# Altium schematic sheet Sheet1.SchDoc
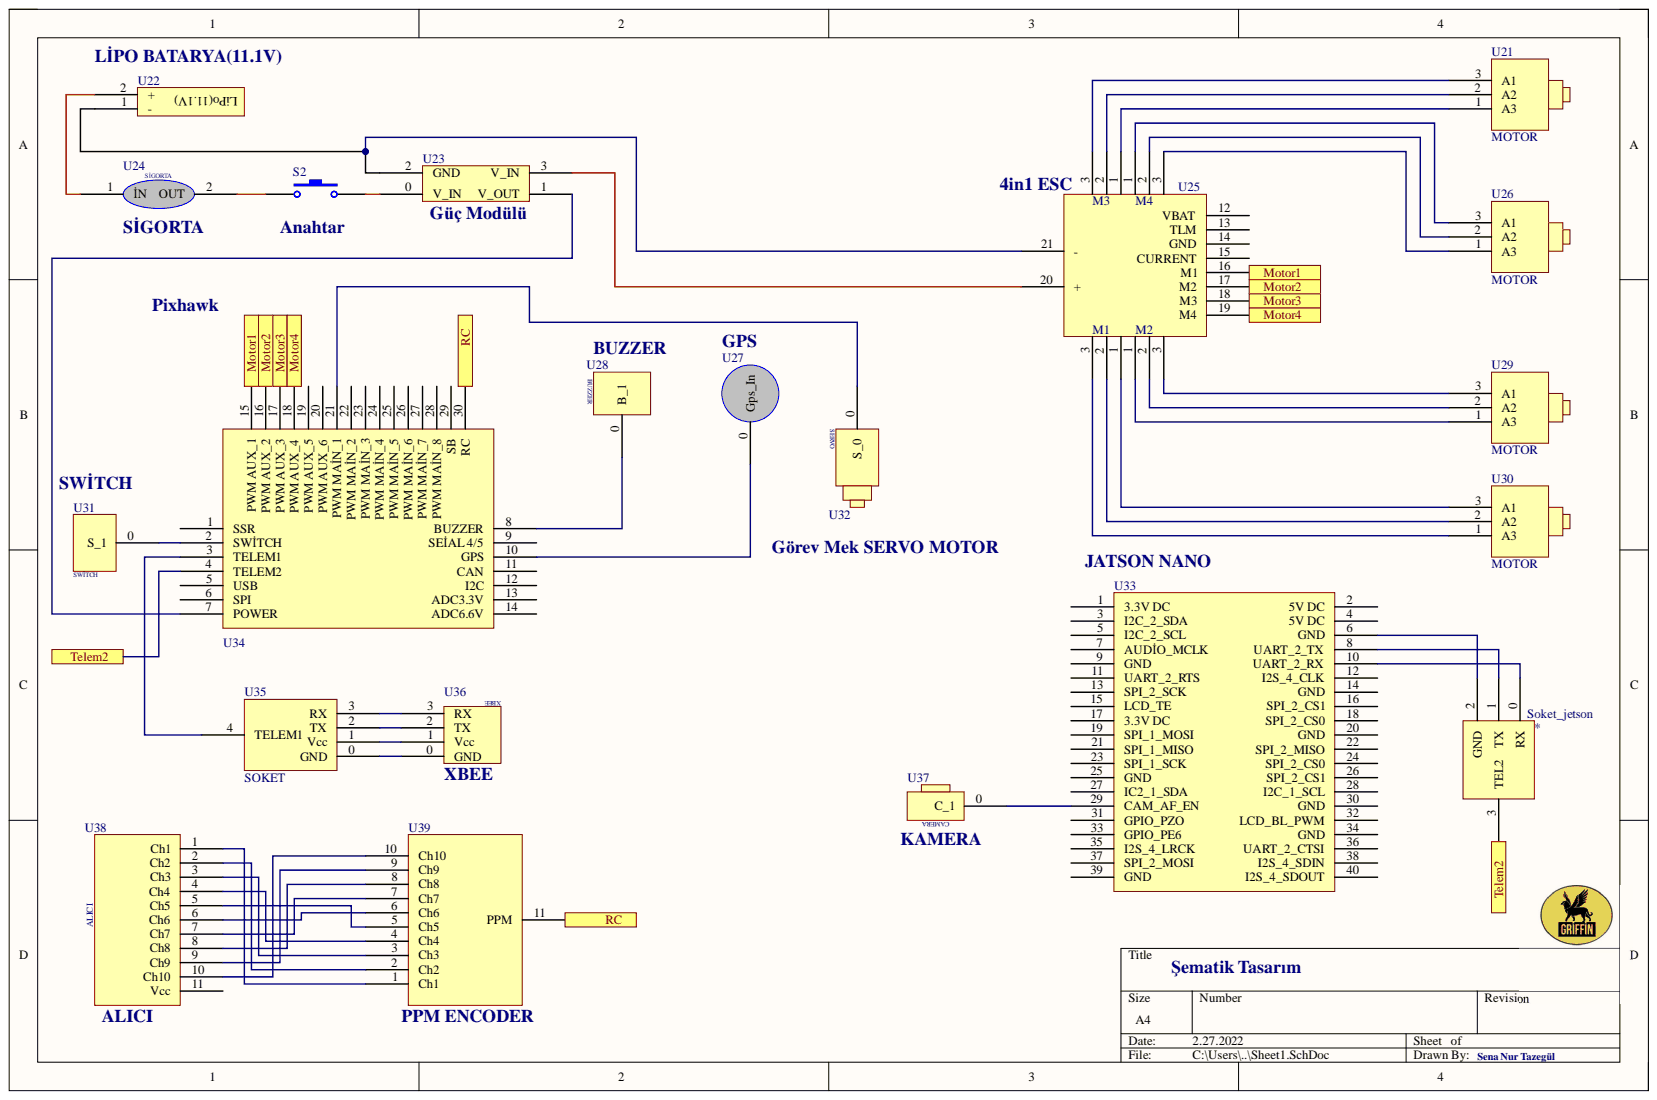
[diagram p0: Sheet1.SchDoc]

## Parts
*  Soket_jetson
S2  Anahtar  [SPST-2]
U21  MOTOR
U22  LİPO BATARYA(11.1V)
U23  (no value)
U24  SİGORTA
U25  4in1 ESC
U26  MOTOR
U27  GPS
U28  BUZZER
U29  MOTOR
U30  MOTOR
U31  SWİTCH
U32  Görev Mek SERVO MOTOR
U33  JATSON NANO
U34  Pixhawk
U35  SOKET
U36  XBEE
U37  KAMERA
U38  ALICI
U39  PPM ENCODER

## Ports
Motor1, Motor2, Motor3, Motor4, RC, Telem2

## Nets
Motor1: U25.16(M1) U34.15(PWM_AUX_1) ; port Motor1
Motor2: U25.17(M2) U34.16(PWM_AUX_2) ; port Motor2
Motor3: U25.18(M3) U34.17(PWM_AUX_3) ; port Motor3
Motor4: U25.19(M4) U34.18(PWM_AUX_4) ; port Motor4
RC: U34.30(RC) U39.11 ; port RC
Telem2: *.3(TEL2) U34.4(TELEM2) ; port Telem2
Net*_0: *.0(RX) U33.10(UART_2_RX)
Net*_1: *.1(TX) U33.8(UART_2_TX)
Net*_2: *.2(GND) U33.6(GND)
NetS2_1: S2.1 U24.2
NetS2_2: S2.2 U23.0(V_IN)
NetU21_1: U21.1 U25.1
NetU21_2: U21.2 U25.2
NetU21_3: U21.3 U25.3
NetU22_1: U22.1 U23.2(GND) U25.21(-)
NetU22_2: U22.2 U24.1
NetU23_1: U23.1(V_OUT) U34.7(POWER)
NetU23_3: U23.3(V_IN) U25.20(+)
NetU25_1: U25.1 U26.3 U29.1 U30.3
NetU25_2: U25.2 U26.2 U29.2 U30.2
NetU25_3: U25.3 U26.1 U29.3 U30.1
NetU27_0: U27.0 U34.10(GPS)
NetU28_0: U28.0 U34.8(BUZZER)
NetU31_0: U31.0 U34.2(SWİTCH)
NetU32_0: U32.0 U34.21(PWM_MAİN_1)
NetU33_29: U33.29(CAM_AF_EN) U37.0
NetU34_3: U34.3(TELEM1) U35.4(TELEM1)
NetU35_0: U35.0(GND) U36.0(GND)
NetU35_1: U35.1(Vcc) U36.1(Vcc)
NetU35_2: U35.2(TX) U36.2(TX)
NetU35_3: U35.3(RX) U36.3(RX)
NetU38_1: U38.1(Ch1) U39.1(Ch1)
NetU38_2: U38.2(Ch2) U39.2(Ch2)
NetU38_3: U38.3(Ch3) U39.3(Ch3)
NetU38_4: U38.4(Ch4) U39.4(Ch4)
NetU38_5: U38.5(Ch5) U39.5(Ch5)
NetU38_6: U38.6(Ch6) U39.6(Ch6)
NetU38_7: U38.7(Ch7) U39.7(Ch7)
NetU38_8: U38.8(Ch8) U39.8(Ch8)
NetU38_9: U38.9(Ch9) U39.9(Ch9)
NetU38_10: U38.10(Ch10) U39.10(Ch10)

Not connected: U25 12(VBAT) 13(TLM) 14(GND) 15(CURRENT); U33 1(3.3V_DC) 2(5V_DC) 3(I2C_2_SDA) 4(5V_DC) 5(I2C_2_SCL) 7(AUDİO_MCLK) 9(GND) 11(UART_2_RTS) 12(I2S_4_CLK) 13(SPI_2_SCK) 14(GND) 15(LCD_TE) 16(SPI_2_CS1) 17(3.3V_DC) 18(SPI_2_CS0) 19(SPI_1_MOSI) 20(GND) 21(SPI_1_MISO) 22(SPI_2_MISO) 23(SPI_1_SCK) 24(SPI_2_CS0) 25(GND) 26(SPI_2_CS1) 27(IC2_1_SDA) 28(I2C_1_SCL) 30(GND) 31(GPIO_PZO) 32(LCD_BL_PWM) 33(GPIO_PE6) 34(GND) 35(I2S_4_LRCK) 36(UART_2_CTSI) 37(SPI_2_MOSI) 38(I2S_4_SDIN) 39(GND) 40(I2S_4_SDOUT); U34 1(SSR) 5(USB) 6(SPI) 9(SEİAL_4/5) 11(CAN) 12(I2C) 13(ADC3.3V) 14(ADC6.6V) 19(PWM_AUX_5) 20(PWM_AUX_6) 22(PWM_MAİN_2) 23(PWM_MAİN_3) 24(PWM_MAİN_4) 25(PWM_MAİN_5) 26(PWM_MAİN_6) 27(PWM_MAİN_7) 28(PWM_MAİN_8) 29(SB); U38 11(Vcc)

## Library data of the parts
S2 = SW-PB: Switch; Component Kind: Standard; LatestRevisionDate: 17-Jul-2002; LatestRevisionNote: Re-released for DXP Platform.; Library Name: Miscellaneous Devices.IntLib; PackageDescription: Switch; 2 Leads; PackageReference: SPST-2; Published: 24-Mar-1999; Publisher: Altium Limited
U23 = GÜÇ MODÜLÜ: Component Kind: Standard; Library Name: Schlib1_TEKNOFEST.SchLib
U32 = SERVO MOTOR: Component Kind: Standard; Library Name: Schlib1_TEKNOFEST.SchLib
* = Soket_jetson: Component Kind: Standard; Library Name: Schlib1_TEKNOFEST.SchLib
U21 U26 U29 U30 = MOTOR: Component Kind: Standard; Library Name: Schlib1_TEKNOFEST.SchLib
U22 = LİPO BATARYA(11.1V): Component Kind: Standard; Library Name: Schlib1_TEKNOFEST.SchLib
U24 = SİGORTA: Component Kind: Standard; Library Name: Schlib1_TEKNOFEST.SchLib
U25 = 4in1 ESC: Component Kind: Standard; Library Name: Schlib1_TEKNOFEST.SchLib
U27 = GPS: Component Kind: Standard; Library Name: Schlib1_TEKNOFEST.SchLib
U28 = BUZZER: Component Kind: Standard; Library Name: Schlib1_TEKNOFEST.SchLib
U31 = SWİTCH: Component Kind: Standard; Library Name: Schlib1_TEKNOFEST.SchLib
U33 = JATSON NANO: Component Kind: Standard; Library Name: Schlib1_TEKNOFEST.SchLib
U34 = pixhawk: Component Kind: Standard; Library Name: Schlib1_TEKNOFEST.SchLib
U35 = SOKET: Component Kind: Standard; Library Name: Schlib1_TEKNOFEST.SchLib
U36 = XBEE: Component Kind: Standard; Library Name: Schlib1_TEKNOFEST.SchLib
U37 = KAMERA: Component Kind: Standard; Library Name: Schlib1_TEKNOFEST.SchLib
U38 = ALICI: Component Kind: Standard; Library Name: Schlib1_TEKNOFEST.SchLib
U39 = PPM ENCODER: Component Kind: Standard; Library Name: Schlib1_TEKNOFEST.SchLib
